# Altium schematic sheet [2] AP3012.SchDoc("[2] AP3012")
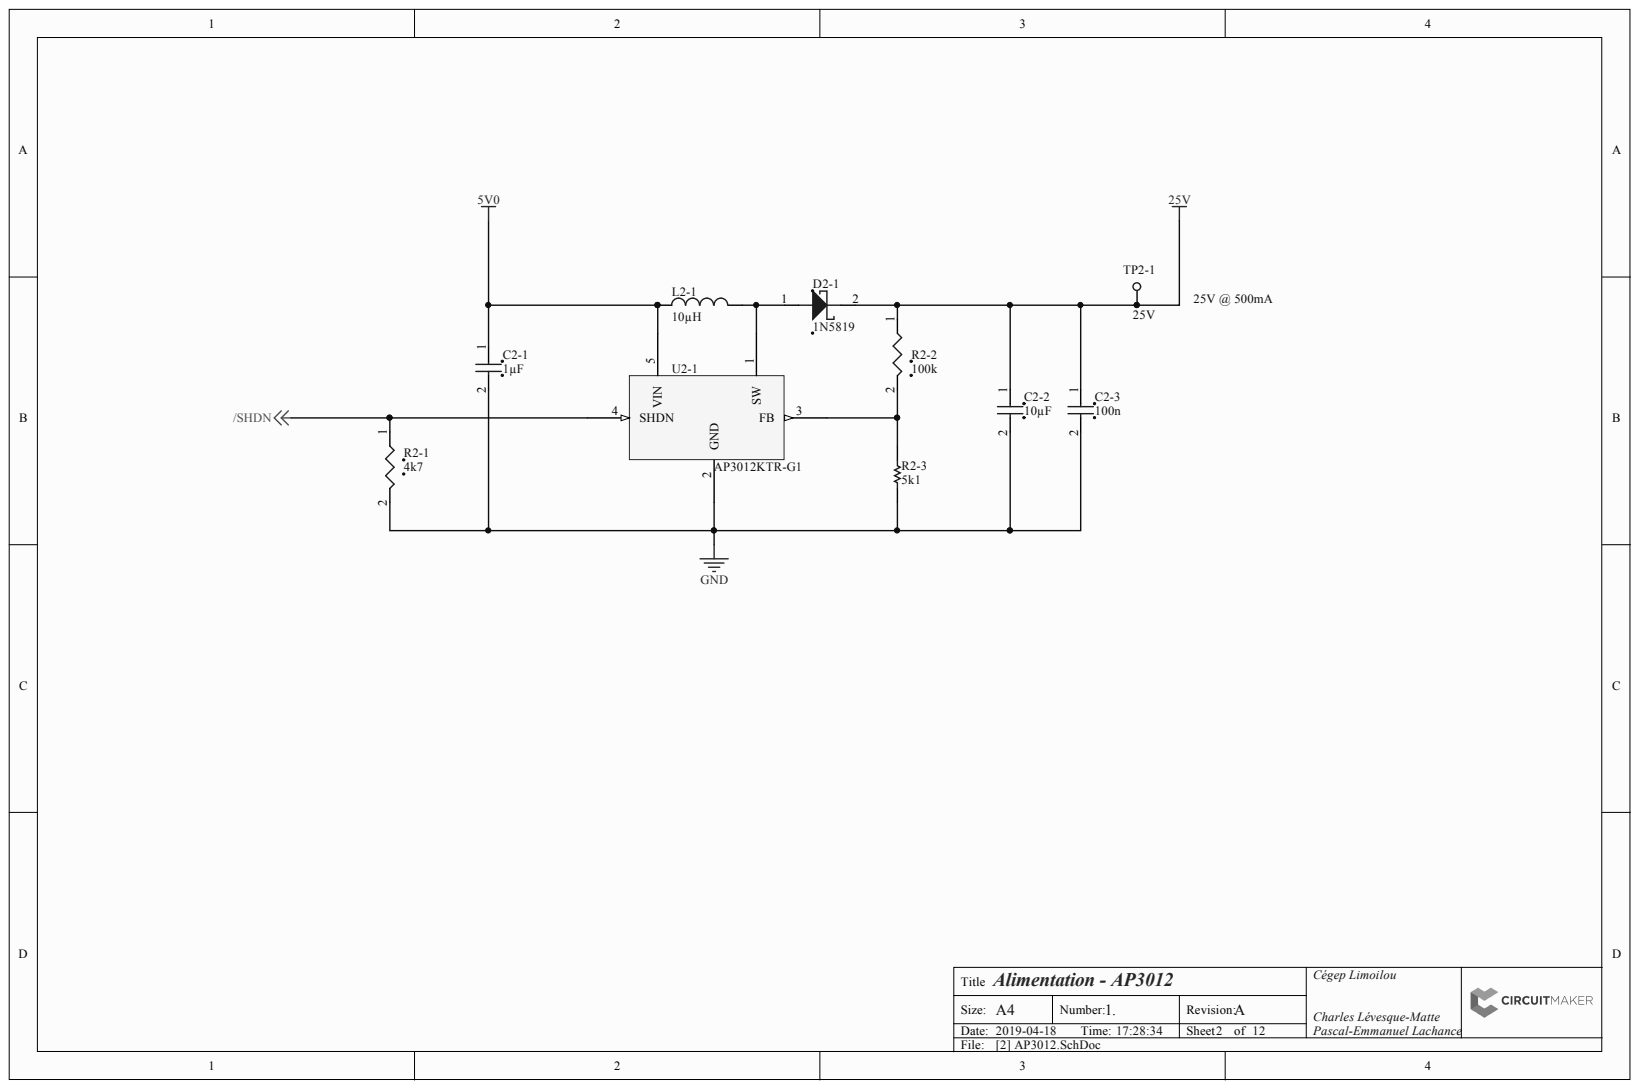
[diagram p0: [2] AP3012.SchDoc]

## Parts
C2-1  1µF  [PCB-nxtc6s20m69g6ouq0bso-1]
C2-2  10µF  [PCB-nxtc6s20m69g6ouq0bso-1]
C2-3  100n  [PCB-mhhqftc5ilnrg4qi8ds6-1]
D2-1  1N5819  [PCB-1z66ntpdcsvy8th2ntjk-1]
L2-1  10µH  [PCB-p3woveu78bl9ib2e2c6z-1]
R2-1  4k7  [PCB-g8ucq7vw1s2sdhfr4zem-1]
R2-2  100k  [PCB-vb332ohng0kley726joo-1]
R2-3  5k1  [PCB-pscu45pf2gecywnmi9sb-1]
TP2-1  25V  [PCB-a7rpxwhzqdkz91v608la-1]
U2-1  AP3012KTR-G1  [PCB-9ejrgpl03flg5xvo1qej-1]

## Nets
5V0: C2-1.1 L2-1.1 U2-1.5(VIN)
25V: C2-2.1 C2-3.1 D2-1.2 R2-2.1 TP2-1.1
/SHDN: R2-1.1 U2-1.4(SHDN)
GND: C2-1.2 C2-2.2 C2-3.2 R2-1.2 R2-3.1 U2-1.2(GND)
NetD2-1_1: D2-1.1 L2-1.2 U2-1.1(SW)
NetR2-2_2: R2-2.2 R2-3.2 U2-1.3(FB)

## Library data of the parts
C2-1 C2-2 = CMP-f7023f64287128c5-1: Manufacturer Part Number: CL10A105KB8NNNC; Capacitance: 1.00 µF; Capacitance Tolerance: ±10%; Dielectric Characteristic: X5R; Lead-Free Status: Lead Free; Voltage Rating (DC): 50.0 V; Case/Package: 0603; Component Kind: Standard; Library Name: CommunityVault; Mounting Style: Surface Mount; OctopartID: f7023f64287128c5; Octopart URL: http://octopart.com/cl10a105kb8nnnc-samsung-23919125; RoHS: Compliant
C2-3 = CMP-1af8d38ac64bc53f-4: Manufacturer: Samsung Electro-Mechanics; Manufacturer Part Number: CL10B104KB8NNNC; Vendor Part Number: 1276-1000-1-ND; Capacitance: 0.1µF; Capacitance Tolerance: ±10%; Dielectric Characteristic: X5R; Lead-Free Status: Lead Free; Temperature Coefficient: X7R; Vendor: Digikey; Voltage - Rated: 50V; Voltage Rating (DC): 50.0 V; Applications: General Purpose; Case/Package: 0603; Component Kind: Standard; HelpURL: https://media.digikey.com/pdf/Data%20Sheets/Samsung%20PDFs/CL_Series_MLCC_ds.pdf; Library Name: CommunityVault; Mounting Style: Surface Mount; Mounting Type: Surface Mount, MLCC; OctopartID: 1af8d38ac64bc53f; Octopart URL: https://octopart.com/cl10b104kb8nnnc-samsung-7928277; Operating Temperature: -55°C ~ 125°C; Package / Case: 0603 (1608 Metric); RoHS: Compliant; Size / Dimension: 0.063" L x 0.031" W (1.60mm x 0.80mm); Thickness (Max): 0.035" (0.90mm); Tolerance: ±10%
D2-1 = CMP-ea0b2305211a35cc-1: Capacitance: 110 pF; Current Rating: 1.00 A; Forward Voltage: 600 mV; Lead-Free Status: Lead Free; Output Current: 1.00 A (max); Polarity: Standard; Voltage Rating (DC): 40.0 V; Case/Package: DO-41; Component Kind: Standard; Library Name: CommunityVault; Lifecycle Status: Active; Manufacturer Part Number: 1N5819; Mounting Style: Through Hole; Number of Pins: 2; OctopartID: ea0b2305211a35cc; Octopart URL: http://octopart.com/1n5819-stmicroelectronics-323191; Packaging: Cut Tape (CT); REACH SVHC Compliance: No SVHC; RoHS: Compliant
L2-1 = CMP-86234fb658fe59e4-1: Inductor Power Shielded Wirewound 10uH 30% 100KHz 11.26Q-Factor Ferrite 1.19A 110mOhm DCR T/R; Manufacturer Part Number: SRR4028-100Y; Inductance: 10.0 µH; Q-Factor: 10.88; Component Kind: Standard; Library Name: FavoritesLibrary; OctopartID: 86234fb658fe59e4; Octopart URL: https://octopart.com/srr4028-100y-bourns-10484667; Packaging: Cut Tape (CT), Tape & Reel (TR); RoHS: Compliant
R2-1 = CMP-011ec9310fadded9-4: Manufacturer Part Number: RMCF0603FT4K70; Lead-Free Status: Lead Free; Power Rating: 100 mW; Resistance: 4.70 kΩ; Resistance Tolerance: ±1%; Case/Package: 0603; Component Kind: Standard; Library Name: CommunityVault; Mounting Style: Surface Mount; OctopartID: 011ec9310fadded9; Octopart URL: https://octopart.com/rmcf0603ft4k70-stackpole+electronics-19213269; Packaging: Tape & Reel (TR); RoHS: Compliant
R2-2 = CMP-5964914b567c86a3-1: Manufacturer Part Number: RMCF0603FT100K; Lead-Free Status: Lead Free; Power Rating: 100 mW; Resistance: 100 kΩ; Resistance Tolerance: ±1%; Case/Package: 0603; Component Kind: Standard; Library Name: CommunityVault; Mounting Style: Surface Mount; OctopartID: 5964914b567c86a3; Octopart URL: https://octopart.com/rmcf0603ft100k-stackpole+electronics-19212926; Packaging: Tape & Reel (TR); RoHS: Compliant
R2-3 = CMP-5db1959e532f8276-1: Res Thick Film 0603 5.1K Ohm 1% 0.1W(1/10W) ±100ppm/C Pad SMD Automotive T/R; Manufacturer Part Number: RMCF0603FT5K10; Lead-Free Status: Lead Free; Power Rating: 100 mW; Resistance: 5.10 k?; Case/Package: 0603; Component Kind: Standard; Library Name: FavoritesLibrary; Mounting Style: Surface Mount; OctopartID: 5db1959e532f8276; Octopart URL: https://octopart.com/rmcf0603ft5k10-stackpole+electronics-19213304; Packaging: Tape & Reel (TR); Resistance Tolerance: ±1%; RoHS: Compliant
TP2-1 = CMP-5j384tb079h9dvgbp04a-2: Through-hole Testpoint; Component Kind: Standard; Library Name: CommunityVault
U2-1 = CMP-576a9fb826ae88ad-6: AP3012 Series 16 V 500 mA 1.5 MHz Surface Mount Boost DC-DC Converter - SOT-25; Lead-Free Status: Lead Free; Component Kind: Standard; Library Name: FavoritesLibrary; Lifecycle Status: Not Listed by Manufacturer; Manufacturer Part Number: AP3012KTR-G1; Mounting Style: Surface Mount; OctopartID: 576a9fb826ae88ad; Octopart URL: https://octopart.com/ap3012ktr-g1-diodes+inc.-30954658; Packaging: Tape & Reel (TR); RoHS: Compliant
